# Altium schematic sheet 02_BGT60LTR11AIP_Shield.SchDoc(02_BGT60LTR11AIP_Shield)
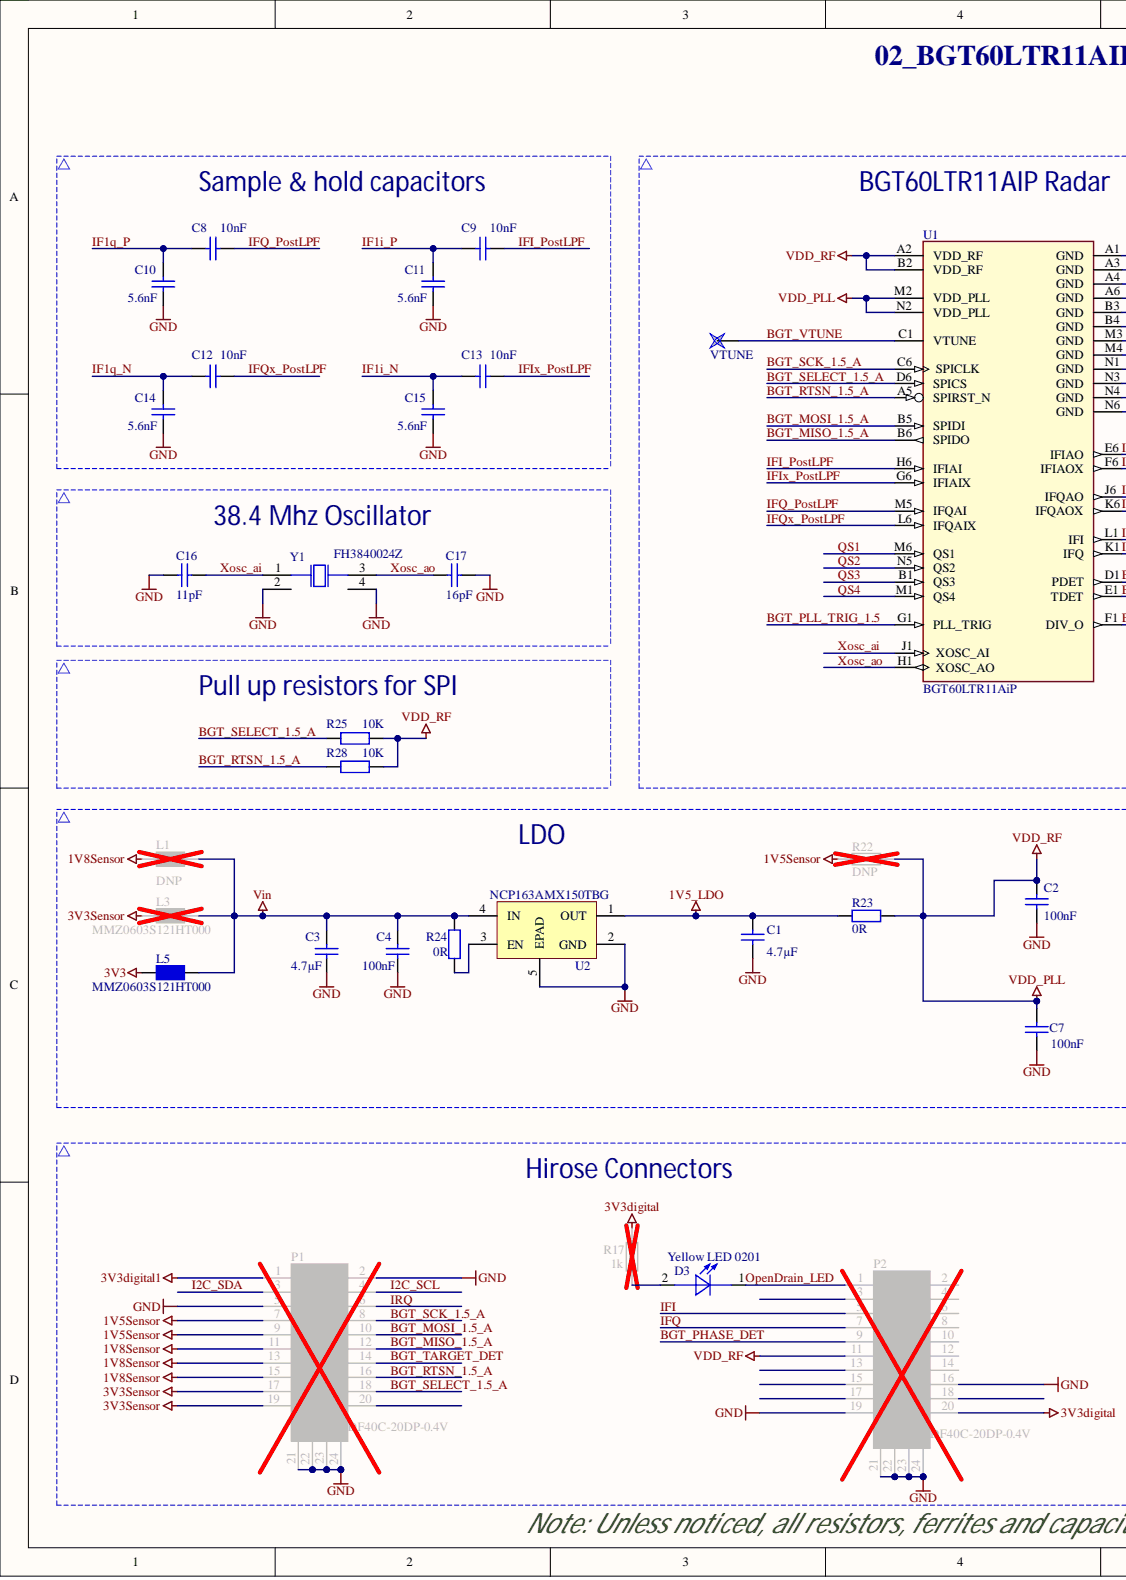
[diagram p0: 02_BGT60LTR11AIP_Shield.SchDoc, left]
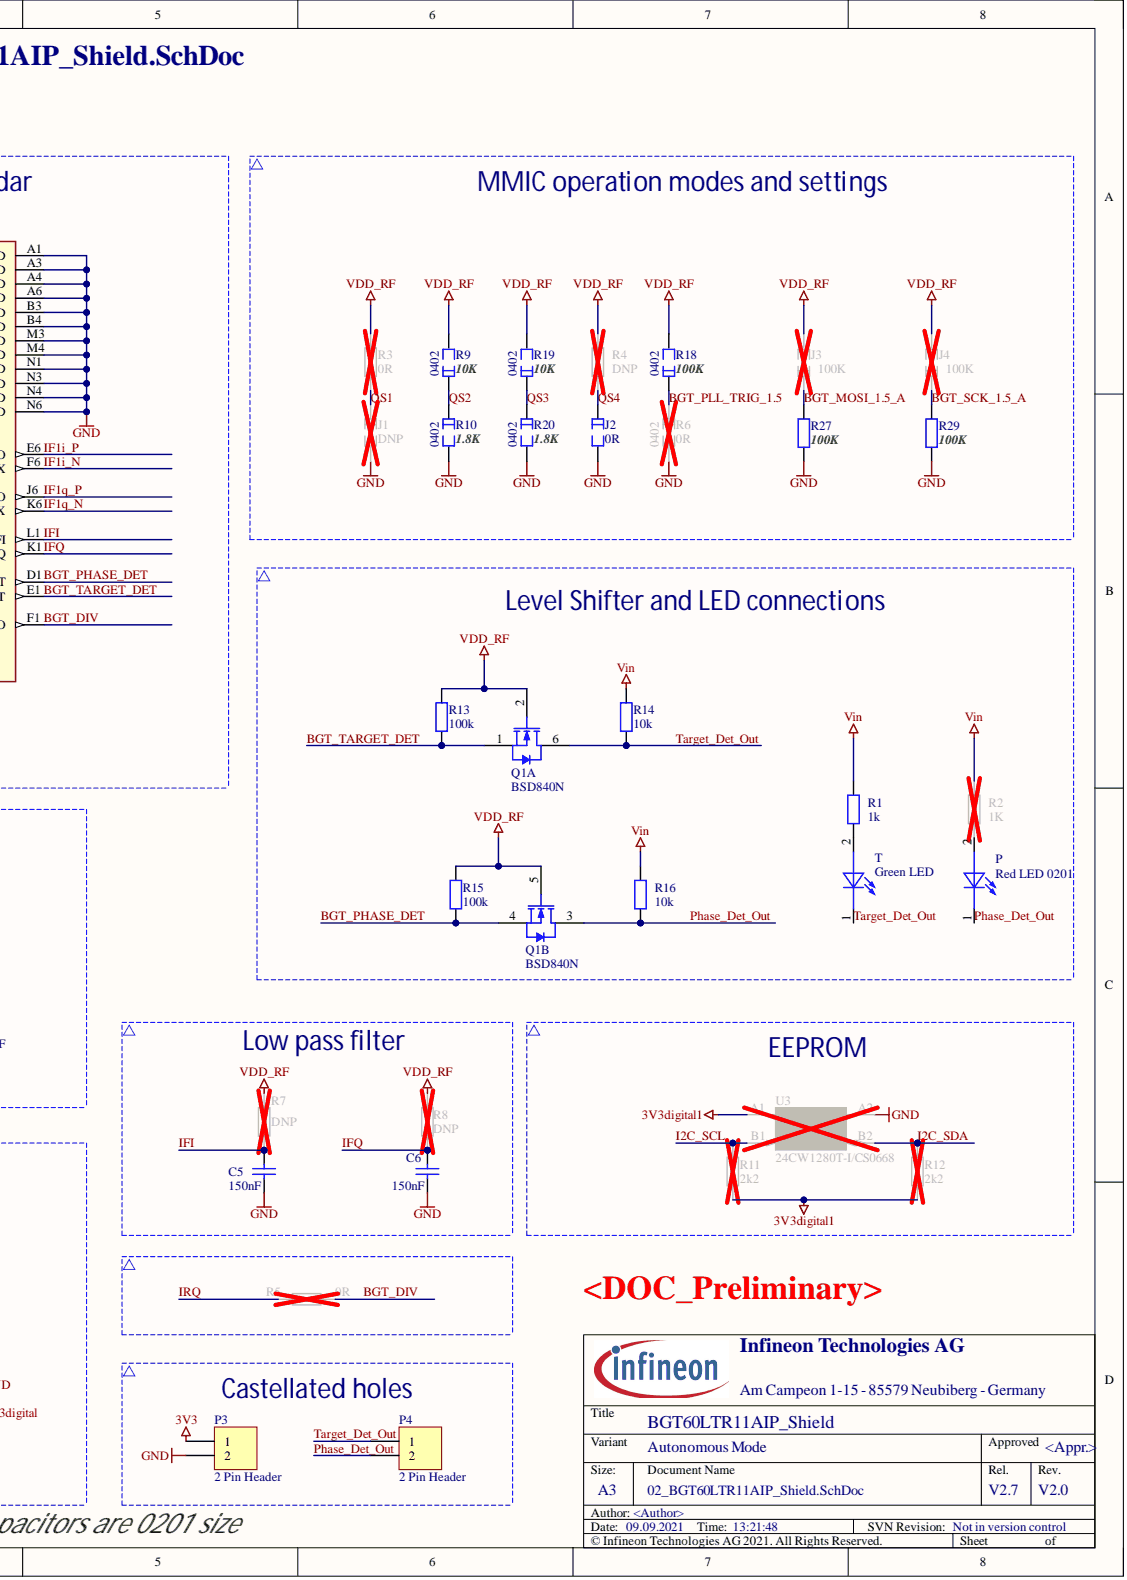
[diagram p1: 02_BGT60LTR11AIP_Shield.SchDoc, right]

## Parts
C1  4.7µF  [C_0201]
C2  100nF  [C_0201]
C3  4.7µF  [C_0201]
C4  100nF  [C_0201]
C5  150nF  [C_0201]
C6  150nF  [C_0201]
C7  100nF  [C_0201]
C8  10nF  [C_0201]
C9  10nF  [C_0201]
C10  5.6nF  [C_0201]
C11  5.6nF  [C_0201]
C12  10nF  [C_0201]
C13  10nF  [C_0201]
C14  5.6nF  [C_0201]
C15  5.6nF  [C_0201]
C16  11pF  [C_0201]
C17  16pF  [C_0201]
D3  Yellow LED 0201  value 0201  PackageReference: 0201  Type: LED  [LED_0201]
J1  DNP  [QS_Jumper]
J2  0R  [QS_Jumper]
J3  100K  [QS_Jumper]
J4  100K  [QS_Jumper]
L1  DNP  [R_0201]
L3  MMZ0603S121HT000  [R_0201]
L5  MMZ0603S121HT000  [R_0201]
P  Red LED 0201  Type: LED  [LED_0201]
P1  DF40C-20DP-0.4V  [DF40C-20DP-0.4V]
P2  DF40C-20DP-0.4V  [DF40C-20DP-0.4V]
P3  2 Pin Header  [HDR1X2_Half]
P4  2 Pin Header  [HDR1X2_Half]
Q1  BSD840N  [INF-PG-SOT-363_N]  (parts A,B)
R1  1k  [R_0201]
R2  1K  [R_0201]
R3  0R  [R_0201]
R4  DNP  [R_0201]
R5  0R  [R_0201]
R6  0R  value 0402  [RES_FastSolder_0402]
R7  DNP  [R_0201]
R8  DNP  [R_0201]
R9  10K  value 0402  [RES_FastSolder_0402]
R10  1.8K  value 0402  [RES_FastSolder_0402]
R11  2k2  [R_0201]
R12  2k2  [R_0201]
R13  100k  [R_0201]
R14  10k  [R_0201]
R15  100k  [R_0201]
R16  10k  [R_0201]
R17  1k  [R_0201]
R18  100K  value 0402  [RES_FastSolder_0402]
R19  10K  value 0402  [RES_FastSolder_0402]
R20  1.8K  value 0402  [RES_FastSolder_0402]
R22  DNP  [R_0201]
R23  0R  [R_0201]
R24  0R  [R_0201]
R25  10K  [R_0201]
R27  100K  [R_0201]
R28  10K  [R_0201]
R29  100K  [R_0201]
T  Green LED  Type: LED  [LED_0201]
U1  BGT60LTR11AiP  [BGA42N50P13X7_670X330X56_new]
U2  NCP163AMX150TBG  [NCP177]
U3  24CW1280T-I/CS0668  [WLCSP4 (CS0)]
VTUNE  Testpoint  [TP0.3MM]  (value not drawn)  (no BOM)
Y1  FH3840024Z  [NDK NX2520SA_NoBoarder]

## Nets
1V5_LDO: C1.2 R23.2 U2.1(OUT)
1V5Sensor: P1.7 P1.9 R22.2
1V8Sensor: L1.1 P1.11 P1.13 P1.15
3V3: L5.1 P3.1
3V3digital: P2.20 R17.2
3V3digital1: P1.1 R11.1 R12.1 U3.A1(VCC)
3V3Sensor: L3.1 P1.17 P1.19
GND: C1.1 C2.1 C3.1 C4.1 C5.2 C6.1 C7.1 C10.1 C11.1 C14.1 C15.1 C16.2 C17.1 J1.1 J2.1 P1.2 P1.5 P1.21 P1.22 P1.23 P1.24 P2.16 P2.19 P2.21 P2.22 P2.23 P2.24 P3.2 R6.1 R10.1 R20.1 R27.1 R29.1 U1.A1(GND) U1.A3(GND) U1.A4(GND) U1.A6(GND) U1.B3(GND) U1.B4(GND) U1.M3(GND) U1.M4(GND) U1.N1(GND) U1.N3(GND) U1.N4(GND) U1.N6(GND) U2.2(GND) U2.5(EPAD) U3.A2(VSS) Y1.2 Y1.4
VDD_PLL: C2.2 C7.2 J3.1 J4.1 P2.11 Q1.2 Q1.5 R3.2 R4.2 R7.2 R8.1 R9.1 R13.2 R15.2 R18.1 R19.1 R22.1 R23.1 R25.1 R28.1 U1.A2(VDD_RF) U1.B2(VDD_RF) U1.M2(VDD_PLL) U1.N2(VDD_PLL)
Vin: C3.2 C4.2 L1.2 L3.2 L5.2 R1.2 R2.2 R14.2 R16.2 R24.2 U2.4(IN)
BGT_DIV: R5.1 U1.F1(DIV_O)
BGT_MISO_1.5_A: P1.12 U1.B6(SPIDO)
BGT_MOSI_1.5_A: J3.2 P1.10 R27.2 U1.B5(SPIDI)
BGT_PHASE_DET: P2.9 Q1.4 R15.1 U1.D1(PDET)
BGT_PLL_TRIG_1.5: R6.2 R18.2 U1.G1(PLL_TRIG)
BGT_RTSN_1.5_A: P1.16 R28.2 U1.A5(SPIRST_N)
BGT_SCK_1.5_A: J4.2 P1.8 R29.2 U1.C6(SPICLK)
BGT_SELECT_1.5_A: P1.18 R25.2 U1.D6(SPICS)
BGT_TARGET_DET: P1.14 Q1.1 R13.1 U1.E1(TDET)
BGT_VTUNE: U1.C1(VTUNE) VTUNE.1
I2C_SCL: P1.4 R11.2 U3.B1(SCL)
I2C_SDA: P1.3 R12.2 U3.B2(SDA)
IF1i_N: C13.1 C15.2 U1.F6(IFIAOX)
IF1i_P: C9.1 C11.2 U1.E6(IFIAO)
IF1q_N: C12.1 C14.2 U1.K6(IFQAOX)
IF1q_P: C8.1 C10.2 U1.J6(IFQAO)
IFI: C5.1 P2.5 R7.1 U1.L1(IFI)
IFI_PostLPF: C9.2 U1.H6(IFIAI)
IFIx_PostLPF: C13.2 U1.G6(IFIAIX)
IFQ: C6.2 P2.7 R8.2 U1.K1(IFQ)
IFQ_PostLPF: C8.2 U1.M5(IFQAI)
IFQx_PostLPF: C12.2 U1.L6(IFQAIX)
IRQ: P1.6 R5.2
OpenDrain_LED: D3.1 P2.1
Phase_Det_Out: P.1 P4.2 Q1.3 R16.1
QS1: J1.2 R3.1 U1.M6(QS1)
QS2: R9.2 R10.2 U1.N5(QS2)
QS3: R19.2 R20.2 U1.B1(QS3)
QS4: J2.2 R4.1 U1.M1(QS4)
Target_Det_Out: P4.1 Q1.6 R14.1 T.1
Xosc_ai: C16.1 U1.J1(XOSC_AI) Y1.1
Xosc_ao: C17.2 U1.H1(XOSC_AO) Y1.3
NetD3_2: D3.2 R17.1
NetP_2: P.2 R2.1
NetR1_1: R1.1 T.2
NetR24_1: R24.1 U2.3(EN)

Not connected: P1 20; P2 2 3 4 6 8 10 12 13 14 15 17 18

## Library data of the parts
C1-C4 C7 C16 C17 = Cap: Capacitor; Value: 0201; Component Kind: Standard; LatestRevisionDate: 17-Jul-2002; LatestRevisionNote: Re-released for DXP Platform.; Library Name: Miscellaneous Devices.IntLib; PackageDescription: Radial Cap, Thru-Hole; 2 Leads; 0.3 in Pin Spacing; PackageReference: RAD-0.3; Published: 8-Jun-2000; Signal Integrity: Cap; Simulation: CAP; Publisher: Altium Limited
C5 C6 C8-C15 = Cap: Component Kind: Standard; Library Name: proj_lib.SchLib
D3 = 392056: XZMYR155W; Manufacturer: Rohm Semiconductors; Manufacturer Component Status: Active; Automotive Qualified: No; Combined Parameters: Vf:2.9V;If:20mA;Ur:5V;Ir:100ÂµA;Pmax:66mW; ComponentStatus: Released; Database Table Name: tParts_all#csv; Legacy DeviceID: DIO00500016; Second Source allowed: Yes; Style: Single; Symbol Type: Standard LED Symbol, 1 Cathode, 2 Anode; Version Number: V01; Application: Standard; Article Number: 392056; Component Kind: Standard; ComponentLink1Description: Vendor WebSite; ComponentLink1URL: http://www.rohm.com; ComponentLink2Description: Datasheet; ComponentLink2URL: http://webnetprod.muc.infineon.com/pcbportal_prod/WorkFlows/AltiumComponent/StandardDocumentation?Versionid=392057; Legacy PartNumber: DIO00500016; Library Name: PCBLibraryData.SVNDblib; Manufacturer Order Number: XZMYR155W; Part Type: Diode; RoHS Compliant: Y; Supplier 1: Farnell; Supplier 2: DigiKey; Supplier 3: Mouser; Supplier Part Number 2: 1497-1299-1-ND; Temperature max: 85; Temperature min: -40; Temperature Range: Industrial
J1-J4 = QS_Jumper: 3 pads for QS pins configuration (soldering needed); Value: 0201; Component Kind: Standard; Library Name: BGT60LTR11_AIP.SchLib
L1 L5 = INDUCTOR1: SMD Ferrite Bead; Hersteller: Würth; Preis: 0; Component Kind: Standard; FootprintBOM: 0805; Kleinmengendistributor: Digi-Key; KleinmengendistributorBestellnummer: 732-1601-1-ND; Library Name: Tjuefire.SchLib
L3 = INDUCTOR1: Hersteller: Würth; Preis: 0; Component Kind: Standard; Description: MMZ0603S121HT000; FootprintBOM: 0805; Kleinmengendistributor: Digi-Key; KleinmengendistributorBestellnummer: 732-1601-1-ND; Library Name: Tjuefire.SchLib
P = 392056: XZMER155W; Manufacturer: Rohm Semiconductors; Manufacturer Component Status: Active; Automotive Qualified: No; Combined Parameters: Vf:2.9V;If:20mA;Ur:5V;Ir:100ÂµA;Pmax:66mW; ComponentStatus: Released; Database Table Name: tParts_all#csv; Second Source allowed: Yes; Style: Single; Symbol Type: Standard LED Symbol, 1 Cathode, 2 Anode; Version Number: V01; Application: Standard; Component Kind: Standard; ComponentLink1Description: Vendor WebSite; ComponentLink1URL: http://www.rohm.com; ComponentLink2Description: Datasheet; ComponentLink2URL: http://webnetprod.muc.infineon.com/pcbportal_prod/WorkFlows/AltiumComponent/StandardDocumentation?Versionid=392057; Library Name: PCBLibraryData.SVNDblib; Manufacturer Order Number: XZMER155W; Part Type: Diode; RoHS Compliant: Y; Supplier 1: Farnell; Supplier 2: DigiKey; Supplier 3: Mouser; Supplier Part Number 2: 1497-1297-1-ND; Temperature max: 85; Temperature min: -40; Temperature Range: Industrial; Value: XZMER155W
P1 P2 = DF40C-20DP-0.4V: Hirose DF40C-20DS-0.4V - 61GHz side; System: Connector; Component Kind: Standard; Library Name: Hatvan.SchLib
P3 = Header 2: Component Kind: Standard; LatestRevisionDate: 17-Jul-2002; LatestRevisionNote: Re-released for DXP Platform.; Library Name: Miscellaneous Connectors.IntLib; Signal Integrity: Connector; Publisher: Altium Limited
P4 = Header 2: Header, 2-Pin; Component Kind: Standard; LatestRevisionDate: 17-Jul-2002; LatestRevisionNote: Re-released for DXP Platform.; Library Name: Miscellaneous Connectors.IntLib; Signal Integrity: Connector; Publisher: Altium Limited
Q1 = BSD840N: N-Channel OptiMOS 2 Small-Signal-Transistor, 20 V VDS, 0.88 A ID, -55 to 150 degC, PG-SOT-363, Reel, Green; Manufacturer: Infineon; Green: TRUE; ID (max): 0.88 A; IDpuls (max): 3.5 A; Ptot (max): 0.5 W; QG: 0.26 nC; RDS (on) (max): 400 mOhm; RDS (on) (max) (@1.8V): 560 mOhm; RthJA (max): 250 K/W; VDS (max): 20 V; VGS(th) (max): 0.75 V; VGS(th) (min): 0.3 V; Component Kind: Standard; ComponentLink1Description: Manufacturer URL; ComponentLink1URL: http://www.infineon.com/; ComponentLink2Description: Datasheet; ComponentLink2URL: http://www.infineon.com/dgdl/BSD840N_Rev2.3_.pdf?folderId=db3a3043156fd573011622e10b5c1f67&fileId=db3a30431b0626df011b12b4486c7c02; ComponentLink3Description: Package Specification; ComponentLink3URL: http://www.infineon.com/dgdl/SOT363-Package_Overview.pdf?folderId=db3a30431936bc4b01195360bd8f3cdc&fileId=db3a30431936bc4b0119536b62bf3cf5; DatasheetVersion: Rev. 2.3; Halogen-free: TRUE; Mounting Technology: Surface Mount; PackageDescription: 6-Pin Small Outline Transistor Package, 2 x 1.25 mm Body, 0.65 mm Pitch; PackageReference: PG-SOT-363; PackageVersion: Apr-08; Packing: Reel; PartNumber: BSD840N; RDS (on) (max) (@2.5V): 400 mOhm; Library Name: BGT60LTR11_AIP.SchLib
R1-R5 R7 R8 R11-R17 R22-R25 R27-R29 = Res2: Resistor; Value: 0201; Component Kind: Standard; Library Name: Miscellaneous Devices.IntLib; Signal Integrity: Res; Simulation: RESISTOR
R6 R9 R10 R18-R20 = RES_FS: Resistor; Component Kind: Standard; Library Name: RES_FastSolder.SchLib; Signal Integrity: Res; Simulation: RESISTOR
T = 392056: XZBGR155W5MAV; Manufacturer: Rohm Semiconductors; Manufacturer Component Status: Active; Automotive Qualified: No; Combined Parameters: Vf:2.9V;If:20mA;Ur:5V;Ir:100ÂµA;Pmax:66mW; ComponentStatus: Released; Database Table Name: tParts_all#csv; Second Source allowed: Yes; Style: Single; Symbol Type: Standard LED Symbol, 1 Cathode, 2 Anode; Version Number: V01; Application: Standard; Article Number: 392056; Component Kind: Standard; ComponentLink1Description: Vendor WebSite; ComponentLink1URL: http://www.rohm.com; ComponentLink2Description: Datasheet; ComponentLink2URL: http://webnetprod.muc.infineon.com/pcbportal_prod/WorkFlows/AltiumComponent/StandardDocumentation?Versionid=392057; Library Name: PCBLibraryData.SVNDblib; Manufacturer Order Number: XZBGR155W5MAV; PackageReference: 0201; Part Type: Diode; RoHS Compliant: Y; Supplier 1: Farnell; Supplier 2: DigiKey; Supplier 3: Mouser; Supplier Part Number 2: 1497-1295-1-ND; Temperature max: 85; Temperature min: -40; Temperature Range: Industrial; Value: XZBGR155W5MAV
U1 = 595740: BGT60LTR11AiP, BGA 42 pins; Manufacturer: Infineon Technologies; Alternate View: No; Combined Parameters: - -;Vdc min/max:-;Iout:-;Fmax:-; Database Table Name: tParts_all#csv; Legacy DeviceID: IFX_BGT60LTR11AiP; PLMStatus: Not Recommended For New Designs; Second Source allowed: Yes; Symbol Type: Low Power 60GHz Doppler Radar Sensor with Antenna; Version Number: V01; Voltage DC (max): na; Article Number: 595740; Component Kind: Standard; ComponentLink1Description: Vendor WebSite; ComponentLink1URL: https://www.infineon.com/; ComponentLink2Description: Datasheet; ComponentLink2URL: http://webnetprod.muc.infineon.com/PCBPortal_Prod/WorkFlows/AltiumComponent/StandardDocumentation?Versionid=595741; Library Name: PCBLibraryData.SVNDblib; Manufacturer Order Number: BGT60LTR11AiP; Muliple Parts: No; Package Description: BGA, SMD, 0.50 mm pitch, 42 pin, 3.30 mm X 6.70 mm X 0.552 mm H Body; Part Type: Integrated Circuit; Supplier 1: Farnell; Supplier 2: DigiKey; Supplier 3: Mouser; Temperature Range: Military; Value: BGT60LTR11AiP
U2 = NCP177: NCP163 - LDO 250mA, XDFN4,  ultra-low noise, high PSRR; Component Kind: Standard; Library Name: Hatvan.SchLib
U3 = 24CW128X: 128K I2C Serial EEPROM with Software Write Protection in CSP4 Package, 0.4mm Pin Pitch; Component Kind: Standard; Library Name: IFXMemory.IntLib
VTUNE = P_PRUEFPAD: Description: Testpoint; FootprintBOM: Testpoint; Preis: -; Library Name: Hatvan.SchLib
Y1 = 409657: Manufacturer: Nihon Dempa Kogyo; Manufacturer Component Status: Active; Automotive Qualified: AEC Q200; Combined Parameters: Standard Standard Crystal; ComponentStatus: Released; Database Table Name: tParts_all#csv; Second Source allowed: Yes; Style: Standard; Symbol type: Standard Crystal Symbol, 4 Pins; Version Number: V01; Application: Standard; Component Kind: Standard; ComponentLink1Description: Vendor WebSite; ComponentLink1URL: http://www.ndk.com/en/; ComponentLink2Description: Datasheet; ComponentLink2URL: http://webnetprod.muc.infineon.com/pcbportal_prod/WorkFlows/AltiumComponent/StandardDocumentation?Versionid=409658; Library Name: PCBLibraryData.SVNDblib; Manufacturer Order Number: FH3840024Z; PackageReference: SMD 2.5 x 2 x 0.6mm; Part Type: Clock; RoHS Compliant: Y; Supplier 1: Farnell; Supplier 2: DigiKey; Supplier 3: Mouser; Supplier Part Number 2: FH3840024ZCT-ND; Temperature max: 85; Temperature min: -30; Type: Crystal; Value: FH3840024Z
